# Altium schematic sheet SCT3288.SchDoc(SCT3288)
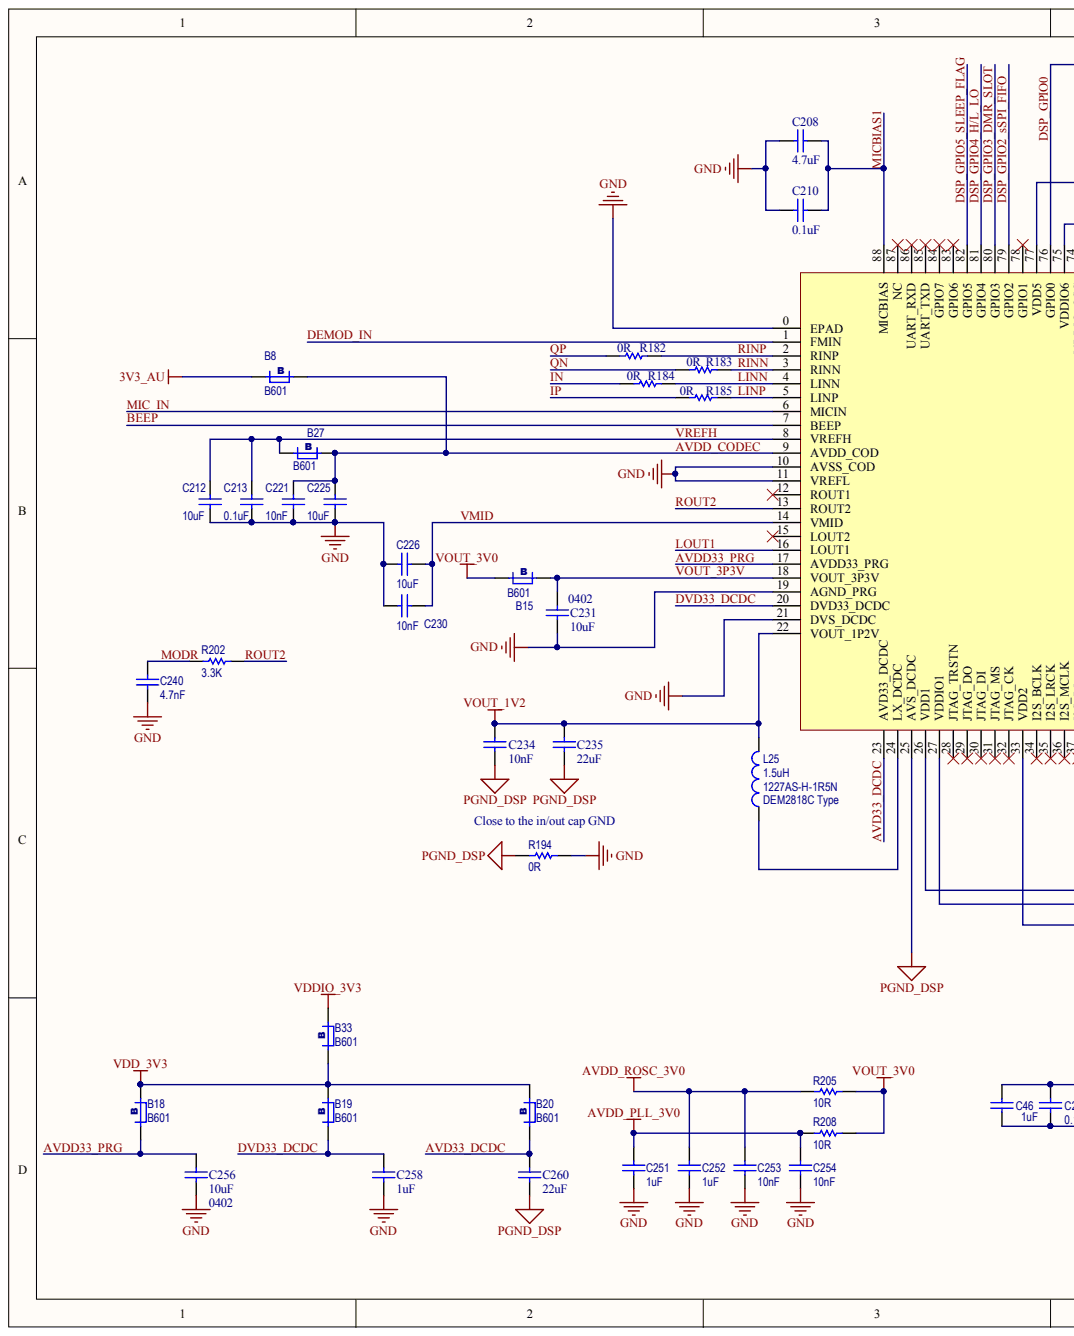
[diagram p0: SCT3288.SchDoc, left]
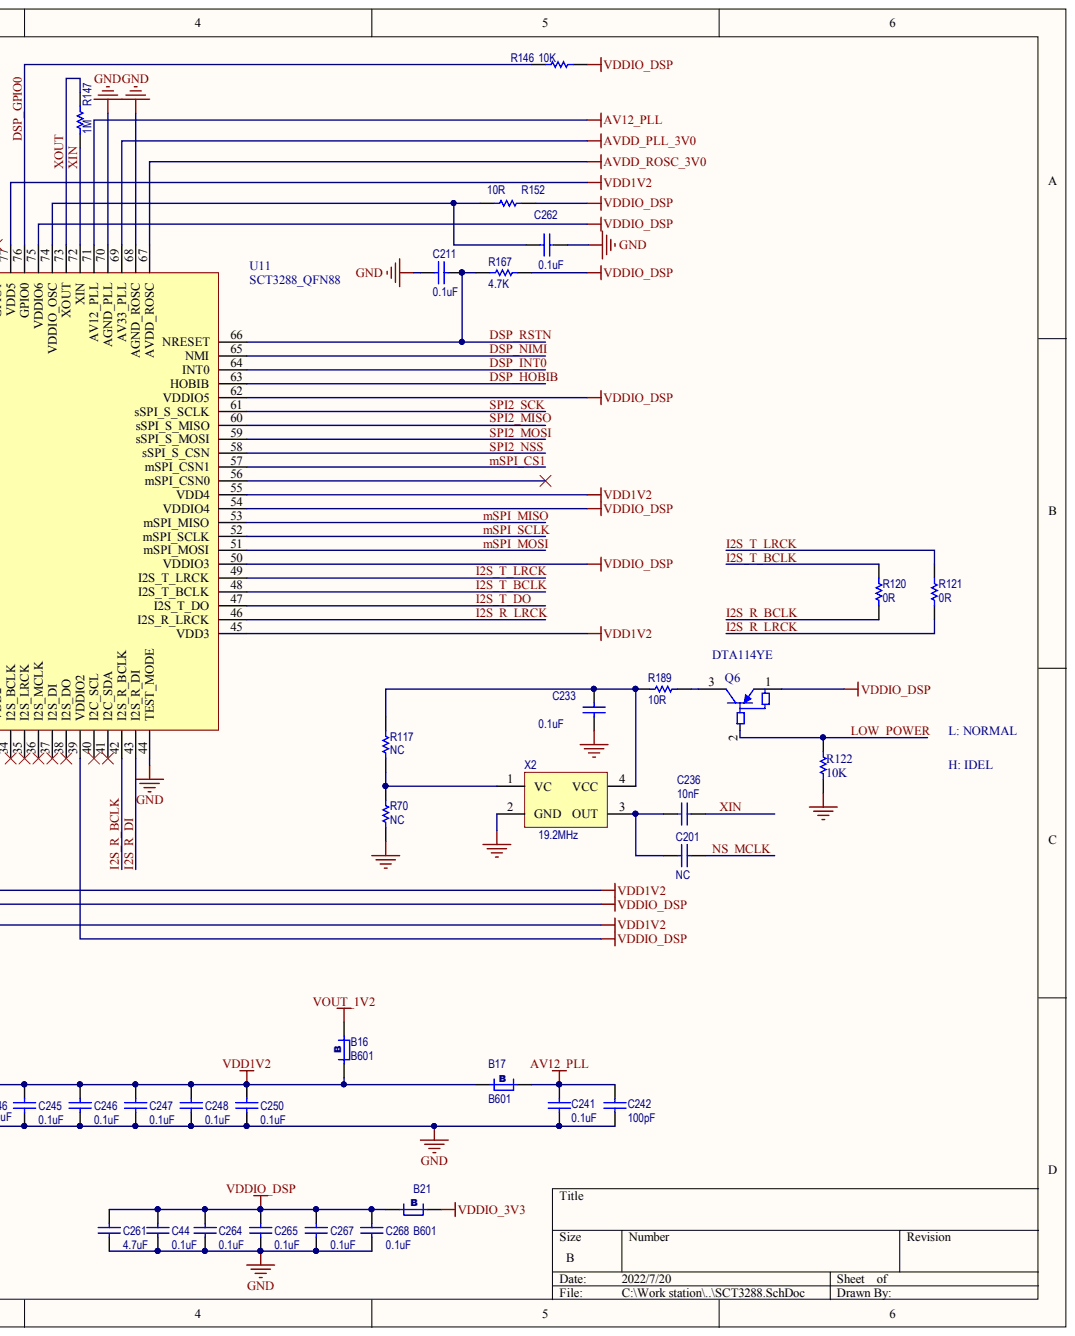
[diagram p1: SCT3288.SchDoc, right]

## Parts
B8  B601  [0402]
B15  B601  [0402]
B16  B601  [0402]
B17  B601  [0402]
B18  B601  [0402]
B19  B601  [0402]
B20  B601  [0402]
B21  B601  [0402]
B27  B601  [0402]
B33  B601  [0402]
C44  0.1uF  [0402]
C46  1uF  [0402]
C201  NC  [0402]
C208  4.7uF  [0603s]
C210  0.1uF  [0402]
C211  0.1uF  [0402]
C212  10uF  value 0.1uF  [0603s]
C213  0.1uF  [0402]
C221  10nF  [0402]
C225  10uF  [0603s]
C226  10uF  [0603s]
C230  10nF  [0402]
C231  10uF  [0603s]
C233  0.1uF  [0402]
C234  10nF  [0402]
C235  22uF  [0805]
C236  10nF  [0402]
C240  4.7nF  [0402]
C241  0.1uF  [0402]
C242  100pF  value 0.1uF  [0402]
C245  0.1uF  [0402]
C246  0.1uF  [0402]
C247  0.1uF  [0402]
C248  0.1uF  [0402]
C250  0.1uF  [0402]
C251  1uF  [0402]
C252  1uF  [0402]
C253  10nF  [0402]
C254  10nF  [0402]
C256  10uF  [0402]
C258  1uF  [0402]
C260  22uF  [0805]
C261  4.7uF  [0402]
C262  0.1uF  [0402]
C264  0.1uF  [0402]
C265  0.1uF  [0402]
C267  0.1uF  [0402]
C268  0.1uF  [0402]
L25  1.5uH  value DEM2818C Type  Publisher: 1227AS-H-1R5N  [IND_2811]
Q6  DTA114YE  [DTA114YE_Jun]
R70  NC  [0402]
R117  NC  [0402]
R120  0R  [0402]
R121  0R  [0402]
R122  10K  [0402]
R146  10K  [0402]
R147  1M  [0402]
R152  10R  [0402]
R167  4.7K  [0402]
R182  0R  [0402]
R183  0R  [0402]
R184  0R  [0402]
R185  0R  [0402]
R189  10R  [0402]
R194  0R  [0402]
R202  3.3K  [0402]
R205  10R  [0402]
R208  10R  [0402]
U11  SCT3288_QFN88  [QFN88]
X2  19.2MHz  [SMD2520_jun]

## Nets
3V3_AU: B8.1
AV12_PLL: B17.1 C241.1 C242.1 U11.71(AV12_PLL)
AVDD_PLL_3V0: C251.2 C254.2 R208.2 U11.69(AV33_PLL)
AVDD_ROSC_3V0: C252.2 C253.2 R205.2 U11.67(AVDD_ROSC)
GND: C44.2 C46.2 C208.2 C210.2 C211.2 C212.1 C213.1 C221.1 C225.1 C226.2 C230.2 C231.1 C233.1 C240.2 C241.2 C242.2 C245.2 C246.2 C247.2 C248.2 C250.2 C251.1 C252.1 C253.1 C254.1 C256.1 C258.1 C261.2 C262.2 C264.2 C265.2 C267.2 C268.2 R70.1 R122.1 R194.1 U11.0(EPAD) U11.10(AVSS_COD) U11.11(VREFL) U11.19(AGND_PRG) U11.21(DVS_DCDC) U11.44(TEST_MODE) U11.68(AGND_ROSC) U11.70(AGND_PLL) X2.2(GND)
PGND_DSP: C234.1 C235.1 C260.1 R194.2 U11.25(AVS_DCDC)
VDD1V2: B16.1 B17.2 C46.1 C245.1 C246.1 C247.1 C248.1 C250.1 U11.26(VDD1) U11.33(VDD2) U11.45(VDD3) U11.55(VDD4) U11.77(VDD5)
VDD_3V3: B18.2 B19.2 B20.2 B33.1
VDDIO_3V3: B21.2 B33.2
VDDIO_DSP: B21.1 C44.1 C261.1 C264.1 C265.1 C267.1 C268.1 Q6.1 R146.1 R152.1 R167.2 U11.27(VDDIO1) U11.39(VDDIO2) U11.50(VDDIO3) U11.54(VDDIO4) U11.62(VDDIO5) U11.75(VDDIO6)
VOUT_1V2: B16.2 C234.2 C235.2 L25.2 U11.22(VOUT_1P2V)
VOUT_3V0: B15.1 R205.1 R208.1
AVD33_DCDC: B20.1 C260.2 U11.23(AVD33_DCDC)
AVDD33_PRG: B18.1 C256.2 U11.17(AVDD33_PRG)
AVDD_CODEC: B8.2 B27.2 C221.2 C225.2 U11.9(AVDD_COD)
BEEP: U11.7(BEEP)
DEMOD_IN: U11.1(FMIN)
DSP_GPIO0: R146.2 U11.76(GPIO0)
DSP_GPIO2_SSPI_FIFO: U11.79(GPIO2)
DSP_GPIO3_DMR_SLOT: U11.80(GPIO3)
DSP_GPIO4_H/L_LO: U11.81(GPIO4)
DSP_GPIO5_SLEEP_FLAG: U11.82(GPIO5)
DSP_HOBIB: U11.63(HOBIB)
DSP_INT0: U11.64(INT0)
DSP_NIMI: U11.65(NMI)
DSP_RSTN: C211.1 R167.1 U11.66(NRESET)
DVD33_DCDC: B19.1 C258.2 U11.20(DVD33_DCDC)
I2S_R_BCLK: R120.2 U11.42(I2S_R_BCLK)
I2S_R_DI: U11.43(I2S_R_DI)
I2S_R_LRCK: R121.2 U11.46(I2S_R_LRCK)
I2S_T_BCLK: R120.1 U11.48(I2S_T_BCLK)
I2S_T_DO: U11.47(I2S_T_DO)
I2S_T_LRCK: R121.1 U11.49(I2S_T_LRCK)
IN: R184.1
IP: R185.1
LINN: R184.2 U11.4(LINN)
LINP: R185.2 U11.5(LINP)
LOUT1: U11.16(LOUT1)
LOW_POWER: Q6.2 R122.2
MIC_IN: U11.6(MICIN)
MICBIAS1: C208.1 C210.1 U11.88(MICBIAS)
MODR: C240.1 R202.2
MSPI_CS1: U11.57(mSPI_CSN1)
MSPI_MISO: U11.53(mSPI_MISO)
MSPI_MOSI: U11.51(mSPI_MOSI)
MSPI_SCLK: U11.52(mSPI_SCLK)
NS_MCLK: C201.1
QN: R183.1
QP: R182.1
RINN: R183.2 U11.3(RINN)
RINP: R182.2 U11.2(RINP)
ROUT2: R202.1 U11.13(ROUT2)
SPI2_MISO: U11.60(sSPI_S_MISO)
SPI2_MOSI: U11.59(sSPI_S_MOSI)
SPI2_NSS: U11.58(sSPI_S_CSN)
SPI2_SCK: U11.61(sSPI_S_SCLK)
VMID: C226.1 C230.1 U11.14(VMID)
VOUT_3P3V: B15.2 C231.2 U11.18(VOUT_3P3V)
VREFH: B27.1 C212.2 C213.2 U11.8(VREFH)
XIN: C236.1 R147.2 U11.72(XIN)
XOUT: R147.1 U11.73(XOUT)
NetC201_2: C201.2 C236.2 X2.3(OUT)
NetC233_2: C233.2 R117.2 R189.2 X2.4(VCC)
NetC262_1: C262.1 R152.2 U11.74(VDDIO_OSC)
NetL25_1: L25.1 U11.24(LX_DCDC)
NetQ6_3: Q6.3 R189.1
NetR70_2: R70.2 R117.1 X2.1(VC)

Not connected: U11 12(ROUT1) 15(LOUT2) 28(JTAG_TRSTN) 29(JTAG_DO) 30(JTAG_DI) 31(JTAG_MS) 32(JTAG_CK) 34(I2S_BCLK) 35(I2S_LRCK) 36(I2S_MCLK) 37(I2S_DI) 38(I2S_DO) 40(I2C_SCL) 41(I2C_SDA) 56(mSPI_CSN0) 78(GPIO1) 83(GPIO6) 84(GPIO7) 85(UART_TXD) 86(UART_RXD) 87(NC)

## Library data of the parts
B8 B15-B21 B27 B33 = B: Component Kind: Standard; Library Name: SCH Lib.SCHLIB; Revision: July-2002: Re-released for DXP Platform.
C44 C211-C213 C233 C241 C242 C245-C248 C250 C262 C264 C265 C267 C268 = Cap Semi: Capacitor (Semiconductor SIM Model); Component Kind: Standard; Library Name: Miscellaneous Devices.IntLib; Published: 8-Jun-2000; Revision: July-2002: Re-released for DXP Platform.; Signal Integrity: Cap Semi; Simulation: CAP; Publisher: Altium Limited
  C44 C211 C213 C233 C241 C245-C248 C250 C262 C264 C265 C267 C268: Value: 0.1uF
C46 C201 C221 C225 C226 C230 C236 C261 = Cap Semi: Capacitor (Semiconductor SIM Model); Value: 0.1uF; Component Kind: Standard; Library Name: Miscellaneous Devices.IntLib; Published: 8-Jun-2000; Revision: July-2002: Re-released for DXP Platform.; Signal Integrity: Cap Semi; Simulation: CAP; Publisher: Altium Limited
C208 C210 C231 C234 C235 C256 C258 C260 = Cap: Capacitor; Manufacturer: Generic Components; Class: Passive; Value: 100pF; Component Kind: Standard; Library Name: Miscellaneous Devices.IntLib; Published: 08/06/2000; Signal Integrity: Cap; Simulation: CAP; SubClass: Capacitor; Publisher: Altium Hobart Technology Centre
C240 C251-C254 = Cap Semi: Capacitor (Semiconductor SIM Model); Manufacturer: Generic Components; Class: Passive; Value: 100pF; Component Kind: Standard; Library Name: Miscellaneous Devices.IntLib; Published: 08/06/2000; Signal Integrity: Cap Semi; Simulation: CAP; SubClass: Capacitor; Publisher: Altium Hobart Technology Centre
L25 = Inductor: Code_IEC: 1005; Value: DEM2818C Type; Code_JEITA: 0402; Component Kind: Standard; Description: Inductor; LatestRevisionDate: 29-May-2009; LatestRevisionNote: IPC-7351 Footprint Added.; Library Name: Miscellaneous Devices.IntLib; PackageDescription: Chip Inductor; PackageReference: 0402-A; Published: 8-Jun-2000; Signal Integrity: Inductor; Simulation: INDUCTOR
Q6 = DTA114YE_Jun: Component Kind: Standard; Library Name: zySCHLIB_Z.SCHLIB
R70 R117 R122 R146 R147 R152 R167 R189 R194 R202 R205 R208 = Res Semi: Semiconductor Resistor; Manufacturer: Generic Components; Class: Passive; Value: 1K; Component Kind: Standard; Library Name: Miscellaneous Devices.IntLib; Published: 08/06/2000; Signal Integrity: Res Semi; Simulation: RES; SubClass: Resistor; Publisher: Altium Hobart Technology Centre
R120 R121 R182-R185 = Res1: Resistor; Manufacturer: Generic Components; Class: Passive; Value: 1K; Component Kind: Standard; Published: 08/06/2000; Signal Integrity: Res1; Simulation: RESISTOR; SubClass: Resistor; Publisher: Altium Hobart Technology Centre
  R120 R121: Library Name: RF.SCHLIB
  R182-R185: Library Name: Miscellaneous Devices.IntLib
X2 = TCXO_12.8MHZ: Component Kind: Standard; Library Name: Schlib1.SchLib
U11 = SCT3288_QFN88: Component Kind: Standard; Library Name: zySCHLIB_Z.SCHLIB
